# Altium schematic sheet Micro_Schematic.SchDoc
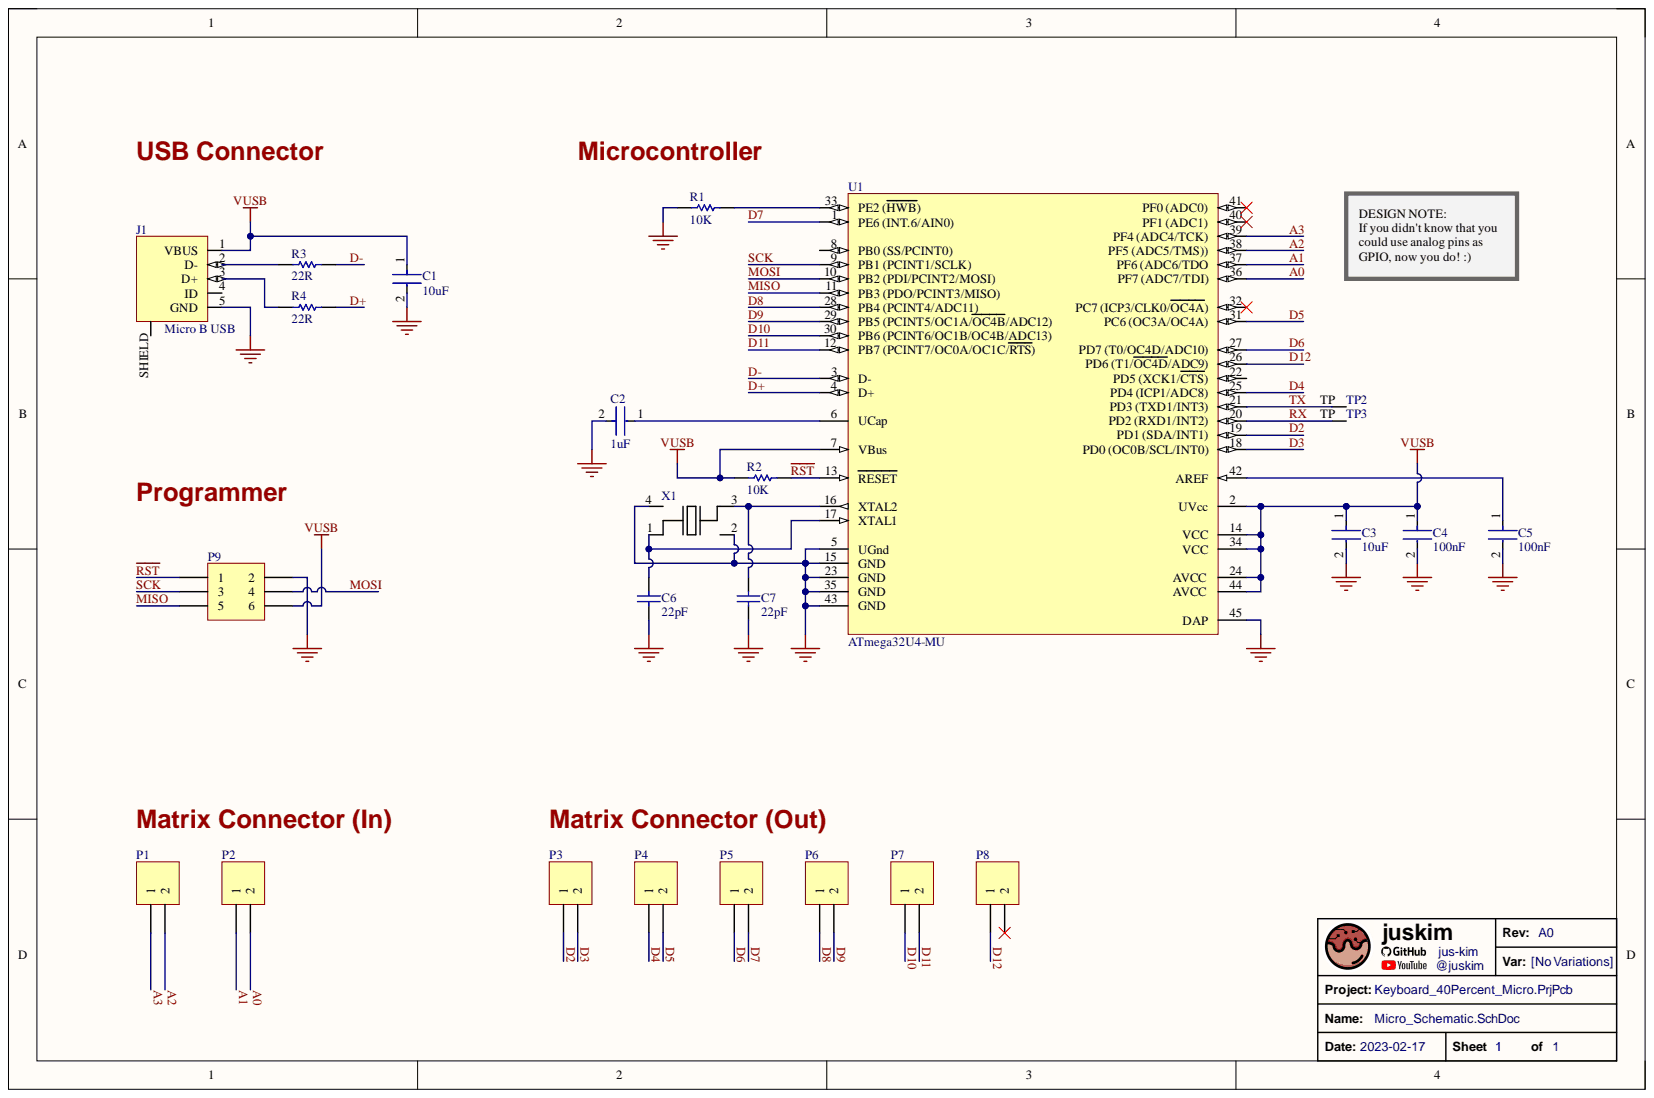
[diagram p0: Micro_Schematic.SchDoc]

## Parts
1  TitleBlock_juskim  (value not drawn)
C1  Capacitance: 10uF  [FP-CL31-IPC_A]  (hidden comment: CL31A106KAHNNNE)
C2  Capacitance: 1uF  [FP-C1608-080-0_1-IPC_B]  (hidden comment: C1608X5R1H105K080AB)
C3  Capacitance: 10uF  [FP-CL31-IPC_A]  (hidden comment: CL31A106KAHNNNE)
C4  Capacitance: 100nF  [FP-CL278-IPC_C]  (hidden comment: CL05A104KA5NNNC)
C5  Capacitance: 100nF  [FP-CL278-IPC_C]  (hidden comment: CL05A104KA5NNNC)
C6  Capacitance: 22pF  [CAPC1005X55X25ML05T05]  (hidden comment: CC0402JRNPO9BN220)
C7  Capacitance: 22pF  [CAPC1005X55X25ML05T05]  (hidden comment: CC0402JRNPO9BN220)
J1  Micro B USB  [MICRO-B-USB-SMD-C40945]
P1  Header 2  [HDR1X2]  (value not drawn)
P2  Header 2  [HDR1X2]  (value not drawn)
P3  Header 2  [HDR1X2]  (value not drawn)
P4  Header 2  [HDR1X2]  (value not drawn)
P5  Header 2  [HDR1X2]  (value not drawn)
P6  Header 2  [HDR1X2]  (value not drawn)
P7  Header 2  [HDR1X2]  (value not drawn)
P8  Header 2  [HDR1X2]  (value not drawn)
P9  Header 3X2  [HDR2X3]  (value not drawn)
R1  Resistance: 10K  [RESC1005X40X25LL05T10]  (hidden comment: RC0402FR-0710KL)
R2  Resistance: 10K  [RESC1005X40X25LL05T10]  (hidden comment: RC0402FR-0710KL)
R3  value 22R  [RES0603]  (hidden comment: CRGH0603J22R)
R4  value 22R  [RES0603]  (hidden comment: CRGH0603J22R)
TP2  Testpoint_1mm  [Testpoint_1mm]  (value not drawn)
TP3  Testpoint_1mm  [Testpoint_1mm]  (value not drawn)
U1  ATmega32U4-MU  [44PW_M]
X1  7F16000E12UCG  [SMD_2016_4P]  (value not drawn)

## Nets
GND: C1.2 C2.2 C3.2 C4.2 C5.2 C6.1 C7.1 J1.5(GND) P9.2 R1.1 U1.5(UGnd) U1.15(GND) U1.23(GND) U1.35(GND) U1.43(GND) U1.45(DAP) X1.2 X1.4
VUSB: C1.1 C3.1 C4.1 J1.1(VBUS) P9.6 R2.1 U1.2(UVcc) U1.7(VBus) U1.14(VCC) U1.24(AVCC) U1.34(VCC) U1.44(AVCC)
A0: P2.2 U1.36(PF7_(ADC7/TDI))
A1: P2.1 U1.37(PF6_(ADC6/TDO)
A2: P1.2 U1.38(PF5_(ADC5/TMS)))
A3: P1.1 U1.39(PF4_(ADC4/TCK))
D2: P3.1 U1.19(PD1_(SDA/INT1))
D3: P3.2 U1.18(PD0_(OC0B/SCL/INT0))
D4: P4.1 U1.25(PD4_(ICP1/ADC8))
D5: P4.2 U1.31(PC6_(OC3A/OC4A))
D6: P5.1 U1.27(PD7_(T0/OC4D/ADC10))
D7: P5.2 U1.1(PE6_(INT.6/AIN0))
D8: P6.1 U1.28(PB4_(PCINT4/ADC11))
D9: P6.2 U1.29(PB5_(PCINT5/OC1A/OC4B/ADC12))
D10: P7.1 U1.30(PB6_(PCINT6/OC1B/OC4B/ADC13))
D11: P7.2 U1.12(PB7_(PCINT7/OC0A/OC1C/RTS))
D12: P8.1 U1.26(PD6_(T1/OC4D/ADC9))
D+: R4.1 U1.4(D+)
D-: R3.1 U1.3(D-)
MISO: P9.5 U1.11(PB3_(PDO/PCINT3/MISO))
MOSI: P9.4 U1.10(PB2_(PDI/PCINT2/MOSI))
~{RST}: P9.1 R2.2 U1.13(RESET)
RX: TP3.TP U1.20(PD2_(RXD1/INT2))
SCK: P9.3 U1.9(PB1_(PCINT1/SCLK))
TX: TP2.TP U1.21(PD3_(TXD1/INT3))
NetC2_1: C2.1 U1.6(UCap)
NetC5_1: C5.1 U1.42(AREF)
NetC6_2: C6.2 U1.17(XTAL1) X1.1
NetC7_2: C7.2 U1.16(XTAL2) X1.3
NetJ1_2: J1.2(D-) R3.2
NetJ1_3: J1.3(D+) R4.2
NetR1_2: R1.2 U1.33(PE2_(HWB))

Not connected: J1 4(ID) SHIELD; P8 2; U1 8(PB0_(SS/PCINT0)) 22(PD5_(XCK1/CTS)) 32(PC7_(ICP3/CLK0/OC4A)) 40(PF1_(ADC1)) 41(PF0_(ADC0))

## Library data of the parts
C1 C3 = CMP-2000-06107-2: Cap Ceramic 10uF 25V X5R ±10% SMD 1206 +85°C Embossed T/R; Case Code (Imperial): 1206; Case Code (Metric): 3216; Case/Package: 1206; Component Kind: Standard; Contact Plating: Tin; Depth: 1.6mm; Dielectric: X5R; Height: 1.6mm; Lead Free: Lead Free; Length: 3.2mm; Library Name: Altium Content Vault; Material: Ceramic; Max Operating Temperature: 85°C; Min Operating Temperature: -55°C; Mount: Surface Mount; Packaging: Tape and Reel; Radiation Hardening: No; Thickness: 0.071inch; Tolerance: 10%; Voltage: 25V; Voltage Rating: 25V; Voltage Rating (DC): 25V; Width: 0.063inch
C2 = CMP-2000-06025-2: Multilayer Ceramic Capacitors 1μF ±10% 50V X5R SMD 0603; Case Code (Imperial): 0603; Case Code (Metric): 1608; Case/Package: 0603; Component Kind: Standard; Construction: Flat; Depth: 0.8mm; Dielectric: X5R; Dielectric Material: Ceramic; Height: 0.8mm; Lead Free: Lead Free; Length: 1.6mm; Library Name: Altium Content Vault; Max Operating Temperature: 85°C; Min Operating Temperature: -55°C; Mount: Surface Mount; Number of Pins: 2; Package Quantity: 1; Packaging: Tape and Reel; Radiation Hardening: No; REACH SVHC: No SVHC; Resistor Type: Ceramic; RoHS Compliant: Yes; Series: C; Termination: SMD/SMT; Thickness: 0.035inch; Tolerance: 10%; Voltage: 50V; Voltage Rating: 50V; Voltage Rating (DC): 50V; Weight: 7.1E-05oz; Width: 0.031inch
C4 C5 = CMP-13271-000728-1: Cap Ceramic 100nF 25V X5R ±10% SMD 0402 +85°C Paper T/R; Case Code (Imperial): 0402; Case Code (Metric): 1005; Case/Package: 0402; Component Kind: Standard; Depth: 0.5mm; Dielectric: X5R; Height: 0.5mm; Lead Free: Lead Free; Length: 1mm; Library Name: Altium Content Vault; Max Operating Temperature: 85°C; Min Operating Temperature: -55°C; Mount: Surface Mount; Package Quantity: 1; Packaging: Tape and Reel; Radiation Hardening: No; Thickness: 0.022inch; Tolerance: 10%; Voltage: 25V; Voltage Rating: 25V; Voltage Rating (DC): 25V; Width: 0.02inch
C6 C7 = CMP-2100-03590-1: Chip Capacitor, 22 pF, +/- 5%, 50 V, -55 to 125 degC, 0402 (1005 Metric), RoHS, Tape and Reel; Manufacturer: Yageo; Dielectric: C0G; Dielectric Material: Ceramic; Insulation Resistance: 100GR; Temperature Coefficient: 30ppm/degC; Voltage Rating: 50V; Case Code (Imperial): 0402; Case Code (Metric): 1005; Case/Package: 0402; Component Kind: Standard; Composition: Ceramic; Datasheet URL: http://www.yageo.com/NewPortal/yageodocoutput?fileName=/pdf/MLCC/UPY-GP_NP0_16V-to-50V_14.pdf; Datasheet Version: Rev. 14, 07/2016; Depth: 0.5mm; Height: 0.5mm; Lead Free: LeadFree; Length: 1mm; Library Name: Altium Content Vault; Manufacturer URL: http://www.yageo.com/; Max Operating Temperature: 125degC; Min Operating Temperature: -55degC; Mount: SurfaceMount; Number of Pins: 2; Package Description: 2-Pin Surface Mount Device, Body 1 x 0.5 mm; Package Quantity: 1; Package Reference: 0402; Packaging: TapeandReel; Radiation Hardening: No; REACH SVHC: NoSVHC; RoHSCompliant: True; Termination: SMD/SMT; Thickness: 0.022inch; Tolerance: 5%; Voltage Rating (DC): 50V; Width: 0.02inch
J1 = 10118194-0001LF: Micro B USB 2.0 Receptacle Connector 5 Position Surface Mount, Right Angle; Through Hole; Mnfr: Amphenol FCI; Component Kind: Standard; Library Name: MySchematics.SchLib
P1-P8 = Header 2: Header, 2-Pin; Component Kind: Standard; LatestRevisionDate: 17-Jul-2002; LatestRevisionNote: Re-released for DXP Platform.; Library Name: Miscellaneous Connectors.IntLib; Signal Integrity: Connector; Publisher: Altium Limited
P9 = Header 3X2: Header, 3-Pin, Dual row; Component Kind: Standard; LatestRevisionDate: 17-Jul-2002; LatestRevisionNote: Re-released for DXP Platform.; Library Name: Miscellaneous Connectors.IntLib; Signal Integrity: Connector; Publisher: Altium Limited
R1 R2 = CMP-2002-07353-1: Manufacturer: Yageo / Phycomp; Component Kind: Standard; Library Name: Altium Content Vault; Part Number: RC0402FR-0710KL
R3 R4 = Res1: Component Kind: Standard; LatestRevisionDate: 17-Jul-2002; LatestRevisionNote: Re-released for DXP Platform.; Library Name: Miscellaneous Devices.IntLib; PackageDescription: Axial Device, Thru-Hole; 2 Leads; 0.3 in Pin Spacing; PackageReference: AXIAL-0.3; Published: 8-Jun-2000; Signal Integrity: Res; Simulation: RESISTOR; Publisher: Altium Limited
U1 = CMP-0095-00166-2: 8-bit AVR Microcontroller, 2.7-5.5V, 16MHz, 32KB Flash, 1KB EEPROM, 2.5KB SRAM, USB Controller, 44-pin QFN, Industrial Grade (-40°C to 85°C), Ext Osc; Code_JEDEC: MO-220-VKKD-1; Component Kind: Standard; ComponentLink1Description: Manufacturer URL; ComponentLink1URL: http://www.atmel.com/; ComponentLink2Description: ATmega16U4/32U4 Datasheet; ComponentLink2URL: http://www.atmel.com/dyn/resources/prod_documents/doc7766.pdf; ComponentLink3Description: ATmega16U4 ATmega32U4 Summary; ComponentLink3URL: http://www.atmel.com/dyn/resources/prod_documents/7766S.pdf; DatasheetVersion: revF, Nov-2010; Library Name: Altium Content Vault; PackageDescription: 44-Pin Quad Flat No-Lead Package, 7 x 7 mm Body, 0.5 mm Pitch (QFN); PackageReference: 44PW; PackageVersion: revG, Sep-2009; RevisionNote: DatasheetVersion, PackageVersion & PCB updated. URLs added.
X1 = FA-238_16.0000MB-C3: 16MHz ±50ppm Crystal 18pF 80 Ohms 4-SMD, No Lead; Mnfr: EPSON; Component Kind: Standard; Library Name: MySchematics.SchLib; Link - DigiKey: https://www.digikey.ca/product-detail/en/epson/FA-238-16.0000MB-C3/SER3686CT-ND/2403459; Link - Mouser: https://www.mouser.ca/ProductDetail/Epson-Timing/FA-238-160000MB-C3?qs=sGAEpiMZZMsBj6bBr9Q9aXTg5R5W%252b%2f%2f8BiqFfhOslgM%3d
1 = TitleBlock_juskim: Component Kind: Standard; Library Name: juskim_SchLib.SchLib
TP2 TP3 = Testpoint_1mm: Component Kind: Standard; Library Name: MySchematics.SchLib
